# Altium schematic sheet SHT20.SchDoc(SHT20)
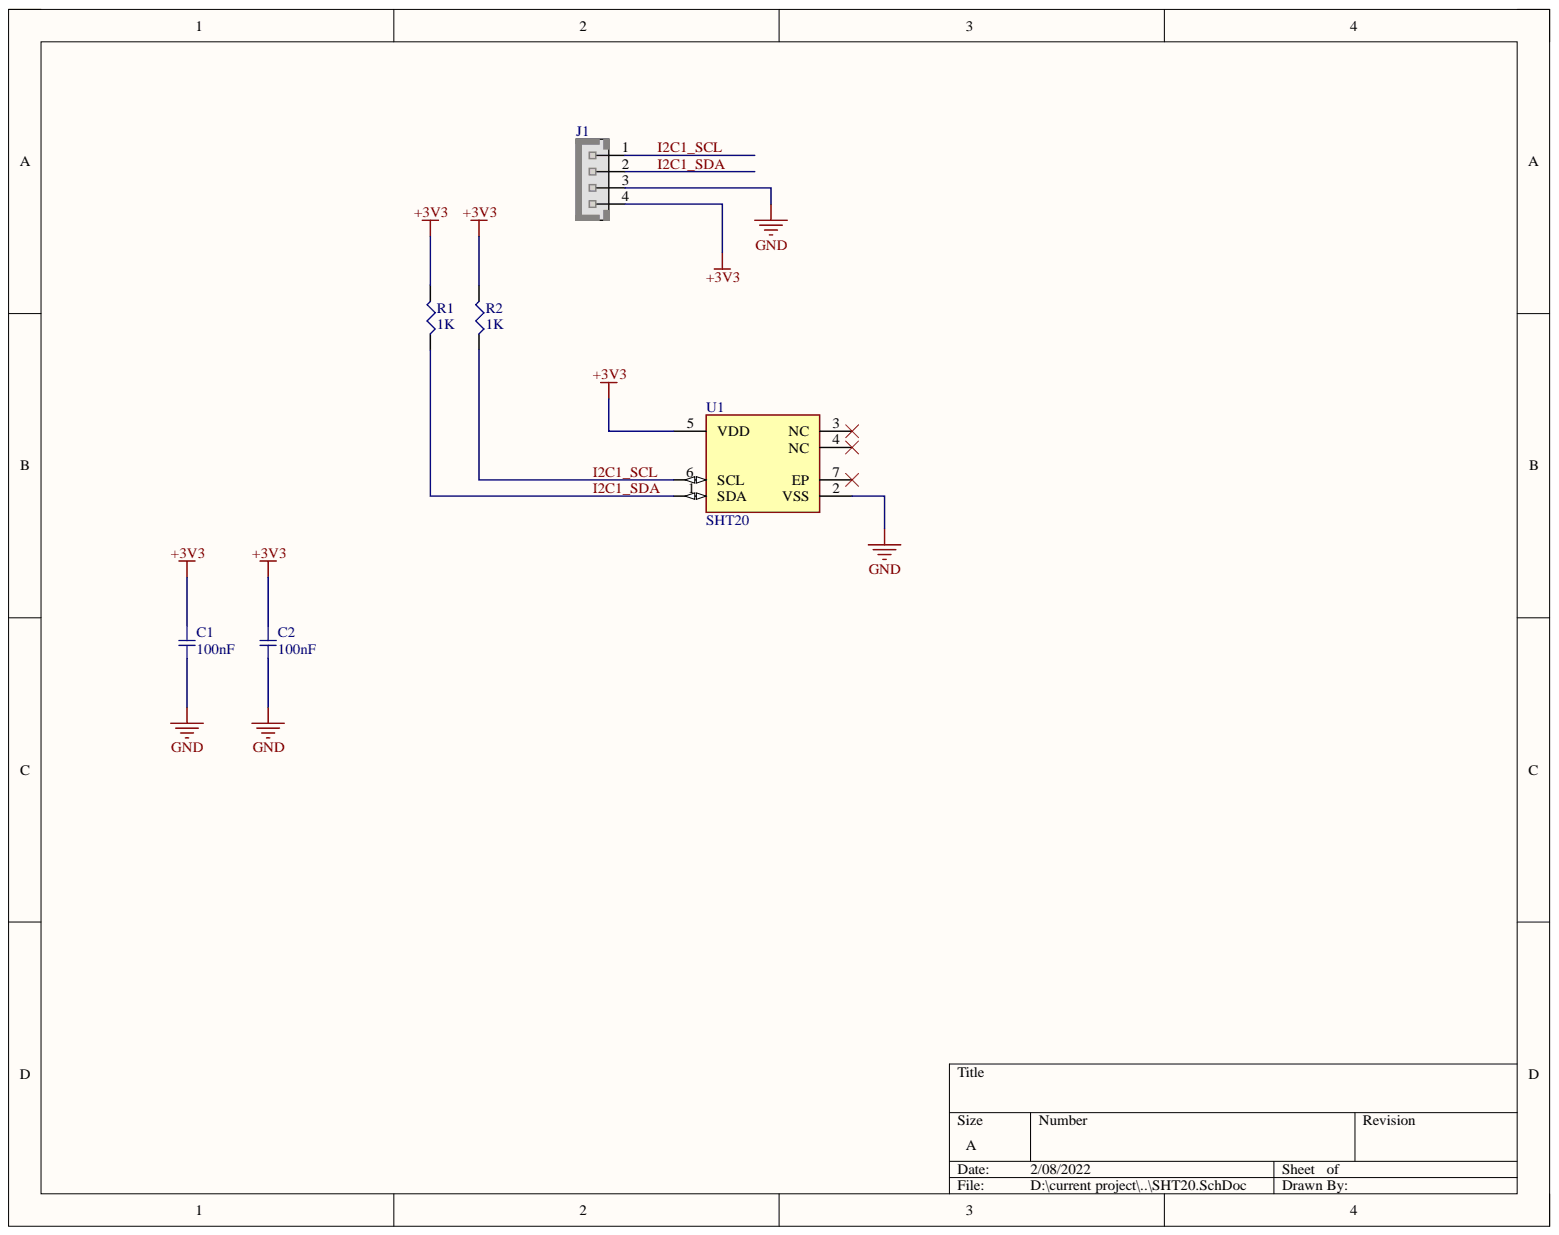
[diagram p0: SHT20.SchDoc]

## Parts
C1  100nF  [SMD-0805C]
C2  100nF  [SMD-0805C]
J1  SHT20 Mod  [XH_4]  (value not drawn)
R1  1K  [SMD-0805-RES]
R2  1K  [SMD-0805-RES]
U1  SHT20  [FP-SHT20-MFG]

## Nets
+3V3: C1.1 C2.1 J1.4 R1.2 R2.2 U1.5(VDD)
GND: C1.2 C2.2 J1.3 U1.2(VSS)
I2C1_SCL: J1.1 R2.1 U1.6(SCL)
I2C1_SDA: J1.2 R1.1 U1.1(SDA)

Not connected: U1 3(NC) 4(NC) 7(EP)

## Library data of the parts
C1 C2 = GC321AD72E103KX01D: CAP CER 100nF 50V X7R 0805; Manufacturer: Murata Electronics North America; @ qty: 0; Minimum Quantity: 1; Temperature Coefficient: X7T; Tolerance: ±10%; Unit Price (USD): 0.3; Value: 10000pF; Voltage: 250V; Applications: Automotive; Component Kind: Standard; Datasheets: http://search.murata.co.jp/Ceramy/image/img/A01X/G101/ENG/GC321AD72E103KX01-01.pdf; Digi-Key Part Number: 490-13986-1-ND; Factory Stock: 0; Features: -; Height - Seated (Max): -; Image: //media.digikey.com/Renders/Murata%20Renders/0805(GC3)200x125.jpg; Lead Spacing: -; Lead Style: -; Library Name: capacitor_murata_0805.IntLib; Mounting Type: Surface Mount, MLCC; Operating Temperature: -55°C ~ 125°C; Package / Case: 0805 (2012 Metric); Packaging: Cut Tape (CT); Part Number: GC321AD72E103KX01D; Part Status: Active; Quantity Available: 4000; Ratings: AEC-Q200; Series: GC3; Size / Dimension: 0.079" L x 0.049" W (2.00mm x 1.25mm); Thickness (Max): 0.039" (1.00mm)
J1 = XH_4: JST-XH pitch: 2.5mm; VALUE: HHDR_4; Component Kind: Standard; Signal Integrity: Connector; Library Name: chila .IntLib
R1 R2 = ERJ-6BQF1R0V: 1KΩ 0805; Manufacturer: Panasonic Electronic Components; @ qty: 1500; Factory Stock: 0; Minimum Quantity: 1; Power (Watts): 0.333W, 1/3W; Temperature Coefficient: ±200ppm/°C; Tolerance: ±1%; Unit Price (USD): 0.05834; Value: 1; Component Kind: Standard; Composition: Thick Film; Datasheets: https://industrial.panasonic.com/cdbs/www-data/pdf/RDA0000/AOA0000C239.pdf; Digi-Key Part Number: P17499CT-ND; Failure Rate: -; Features: Automotive AEC-Q200, Current Sense; Height - Seated (Max): 0.028" (0.70mm); Image: //media.digikey.com/Renders/Panasonic%20Renders/ERJ-0805%20Pkg.jpg; Library Name: Panasonic_ERJ_0805.IntLib; Number of Terminations: 2; Operating Temperature: -55°C ~ 125°C; Package / Case: 0805 (2012 Metric); Packaging: Cut Tape (CT); Part Number: ERJ-6BQF1R0V; Part Status: Active; Quantity Available: 808; Series: ERJ; Size / Dimension: 0.079" L x 0.049" W (2.00mm x 1.25mm); Supplier Device Package: 0805
U1 = CMP-2000-05344-2: SENSOR HUMID/TEMP 3V I2C 3% SMD; Manufacturer: Sensirion; Automotive Grade: Grade 1; Max Supply Voltage: 3.6V; Min Supply Voltage: 2.1V; Operating Supply Current: 300uA; Resolution: 14bits; Accuracy: 3%; Automotive: Yes; Category: IC; Component Kind: Standard; ComponentLink2Description: Datasheet; ComponentLink2URL: https://www.sensirion.com/fileadmin/user_upload/customers/sensirion/Dokumente/0_Datasheets/Humidity/Sensirion_Humidity_Sensors_SHT20_Datasheet.pdf; Device Class L1: Sensors; Device Class L2: Temperature and Humidity Sensors; Device Class L3: unset; Digikey Description: SENSOR HUMID/TEMP 3V I2C 3% SMD; Height: 1.1mm; Interface: I2C; Ipc Land Pattern Name: DFN300X300X110-6; Lead Free: Yes; Library Name: Altium Content Vault; Manufacturer Part Number: SHT20; Octopart: https://octopart.com/sht20-sensirion-47077745; Package: 0; RoHS: TRUE; Sensor Output: I2C; Temperature: -40°C to +125°C; Temperature Range High: +125°C; Temperature Range Low: -40°C
